# Altium schematic sheet Extra3_test_points.SchDoc
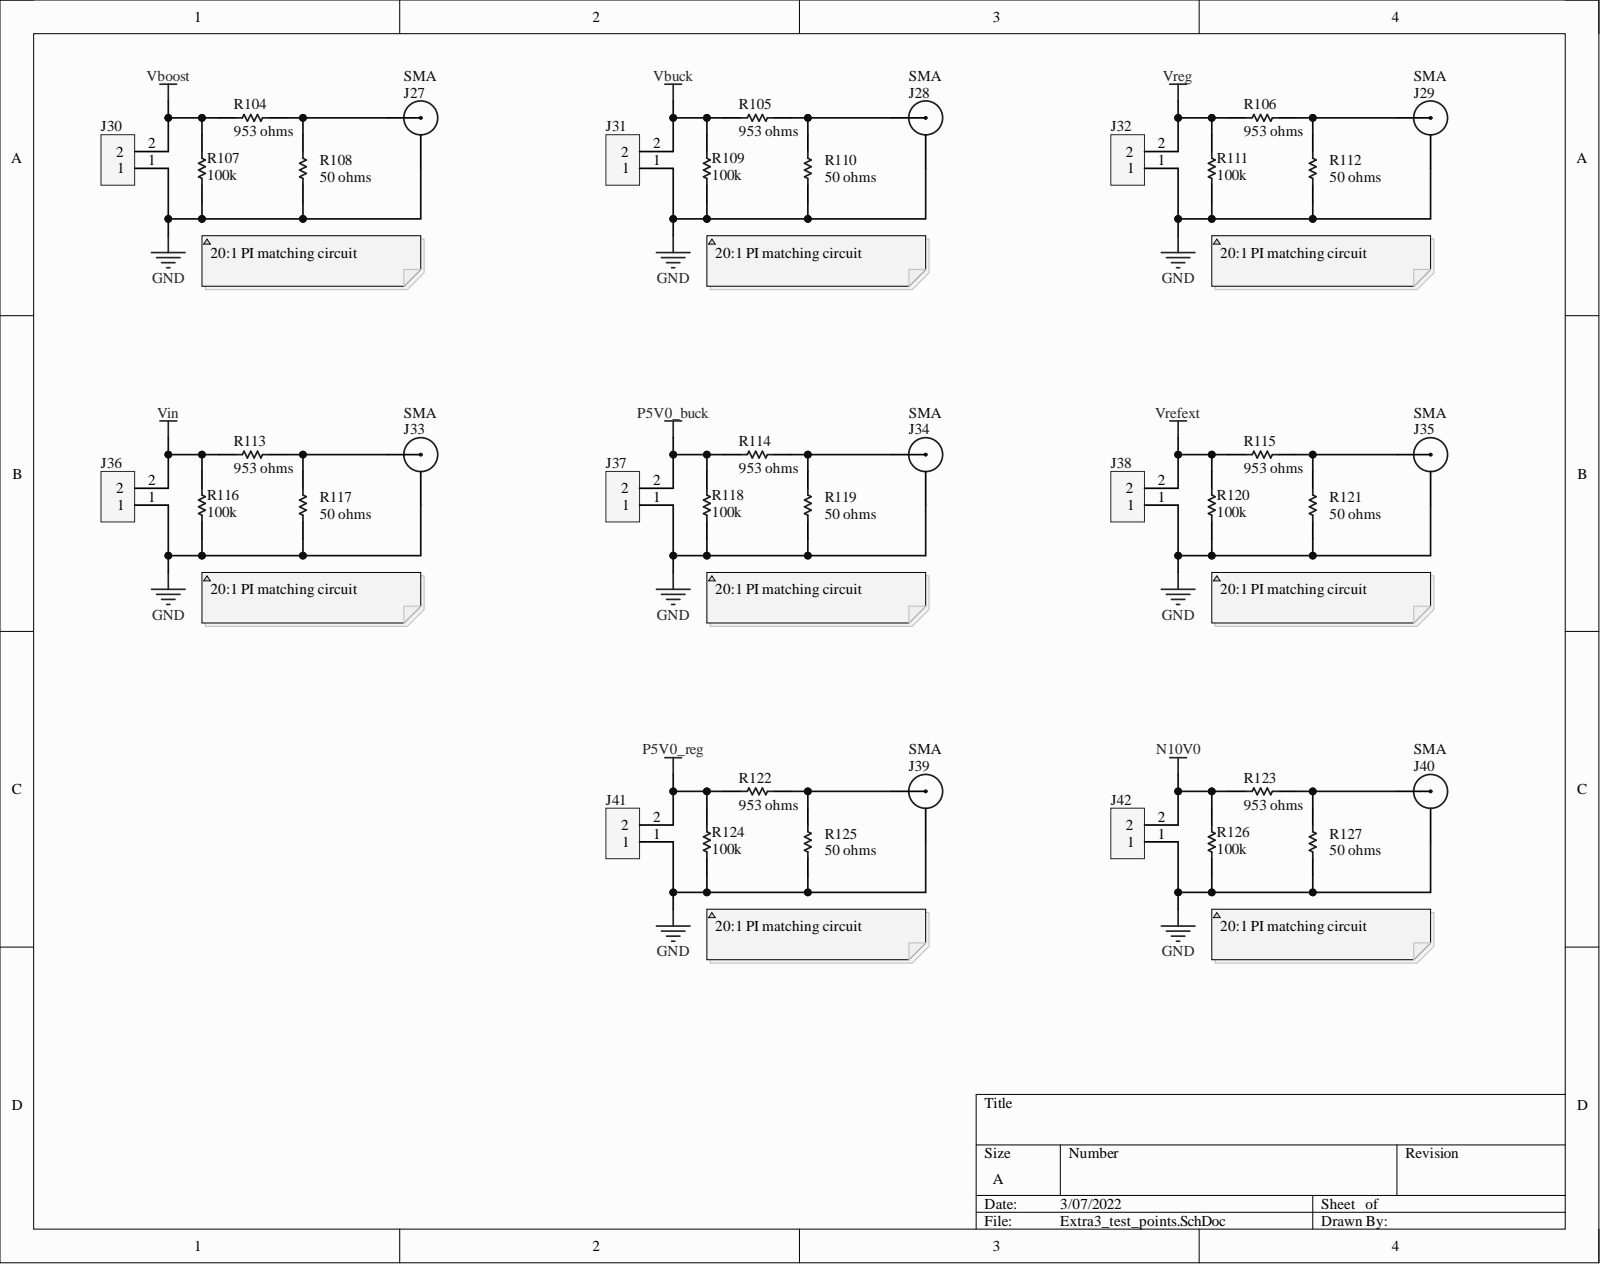
[diagram p0: Extra3_test_points.SchDoc]

## Parts
J27  SMA  [Amphenol RF 901-10511-2-Footprint-1]
J28  SMA  [Amphenol RF 901-10511-2-Footprint-1]
J29  SMA  [Amphenol RF 901-10511-2-Footprint-1]
J30  (no value)  [PCB-omgsbkhp90n7lpri88og-1]
J31  (no value)  [PCB-omgsbkhp90n7lpri88og-1]
J32  (no value)  [PCB-omgsbkhp90n7lpri88og-1]
J33  SMA  [Amphenol RF 901-10511-2-Footprint-1]
J34  SMA  [Amphenol RF 901-10511-2-Footprint-1]
J35  SMA  [Amphenol RF 901-10511-2-Footprint-1]
J36  (no value)  [PCB-omgsbkhp90n7lpri88og-1]
J37  (no value)  [PCB-omgsbkhp90n7lpri88og-1]
J38  (no value)  [PCB-omgsbkhp90n7lpri88og-1]
J39  SMA  [Amphenol RF 901-10511-2-Footprint-1]
J40  SMA  [Amphenol RF 901-10511-2-Footprint-1]
J41  (no value)  [PCB-omgsbkhp90n7lpri88og-1]
J42  (no value)  [PCB-omgsbkhp90n7lpri88og-1]
R104  953 ohms  [RESC2013X50X30NL20T20]
R105  953 ohms  [RESC2013X50X30NL20T20]
R106  953 ohms  [RESC2013X50X30NL20T20]
R107  100k  [PCB-p00ux61zw156yfuk4gsu-1]
R108  50 ohms  [PCB-4097432-1]
R109  100k  [PCB-p00ux61zw156yfuk4gsu-1]
R110  50 ohms  [PCB-4097432-1]
R111  100k  [PCB-p00ux61zw156yfuk4gsu-1]
R112  50 ohms  [PCB-4097432-1]
R113  953 ohms  [RESC2013X50X30NL20T20]
R114  953 ohms  [RESC2013X50X30NL20T20]
R115  953 ohms  [RESC2013X50X30NL20T20]
R116  100k  [PCB-p00ux61zw156yfuk4gsu-1]
R117  50 ohms  [PCB-4097432-1]
R118  100k  [PCB-p00ux61zw156yfuk4gsu-1]
R119  50 ohms  [PCB-4097432-1]
R120  100k  [PCB-p00ux61zw156yfuk4gsu-1]
R121  50 ohms  [PCB-4097432-1]
R122  953 ohms  [RESC2013X50X30NL20T20]
R123  953 ohms  [RESC2013X50X30NL20T20]
R124  100k  [PCB-p00ux61zw156yfuk4gsu-1]
R125  50 ohms  [PCB-4097432-1]
R126  100k  [PCB-p00ux61zw156yfuk4gsu-1]
R127  50 ohms  [PCB-4097432-1]

## Nets
GND: J27.2 J28.2 J29.2 J30.1 J31.1 J32.1 J33.2 J34.2 J35.2 J36.1 J37.1 J38.1 J39.2 J40.2 J41.1 J42.1 R107.1 R108.1 R109.1 R110.1 R111.1 R112.1 R116.1 R117.1 R118.1 R119.1 R120.1 R121.1 R124.1 R125.1 R126.1 R127.1
N10V0: J42.2 R123.1 R126.2
P5V0_buck: J37.2 R114.1 R118.2
P5V0_reg: J41.2 R122.1 R124.2
Vboost: J30.2 R104.1 R107.2
Vbuck: J31.2 R105.1 R109.2
Vin: J36.2 R113.1 R116.2
Vrefext: J38.2 R115.1 R120.2
Vreg: J32.2 R106.1 R111.2
NetJ27_1: J27.1 R104.2 R108.2
NetJ28_1: J28.1 R105.2 R110.2
NetJ29_1: J29.1 R106.2 R112.2
NetJ33_1: J33.1 R113.2 R117.2
NetJ34_1: J34.1 R114.2 R119.2
NetJ35_1: J35.1 R115.2 R121.2
NetJ39_1: J39.1 R122.2 R125.2
NetJ40_1: J40.1 R123.2 R127.2

## Library data of the parts
J27-J29 J33-J35 J39 J40 = CMP-c4510937ad5a1b96-2: RF Connector SMA PCB End Launch Jack 50 Ohm Round Flange with Flats High Freq 0.010 Pin - Footprint specifically for OSH Park 4 Layer!; Manufacturer Part Number: 901-10511-2; Component Kind: Standard; Contact Material: Beryllium Copper; Contact Plating: Gold; Footprint1NoteToUser: Footprint-1 designed specifically for OSH Park 4 Layer Process; Library Name: My Personal Space; Octopart Url: https://octopart.com/901-10511-2-amphenol+rf-74206317; OctopartID: c4510937ad5a1b96; RoHS: Compliant
J30-J32 J36-J38 J41 J42 = CMP-748fbcb73d6bab48-30: Pitch 2.54 mm, Single Row, 2pins; Component Kind: Standard; Library Name: My Personal Space
R104-R106 R113-R115 R122 R123 = CMP-1867576-2: RES SMD 953 OHM 1% 1/8W 0805; Manufacturer Part Number: CRCW0805953RFKEA; Case/Package (SI): 2012; Lead-Free Status: Lead Free; Power Rating: 0.125 W; Resistance: 953.0 Ω; Temperature Coefficient: 100.0 ppm/°C; Voltage Rating (DC): 150.0 V; Case/Package: 0805; Component Kind: Standard; Library Name: My Personal Space; Mounting Style: Surface Mount; Number of Pins: 2; Octopart Url: https://octopart.com/crcw0805953rfkea-vishay+dale-39800147; OctopartID: 3280f9d55ab5ed47; REACH SVHC Compliance: No SVHC; Resistance Tolerance: ±1%; RoHS: Compliant; Size-Height: 0.00045 m; Size-Length: 0.002 m; Size-Width: 0.00125 m
R107 R109 R111 R116 R118 R120 R124 R126 = CMP-1854318-20: Res Thick Film 0805 100K Ohm 1% 1/8W ±100ppm/°C Molded SMD Paper T/R; Manufacturer Part Number: RC0805FR-07100KL; Case/Package (SI): 2012; Lead-Free Status: Lead Free; Power Rating: 125 mW; Resistance: 100 kΩ; Temperature Coefficient: -100 ppm/°C to 100 ppm/°C; Voltage Rating (DC): 150 V; Case/Package: 0805; Component Kind: Standard; Library Name: My Personal Space; Mounting Style: Surface Mount; Number of Pins: 2; Octopart URL: https://octopart.com/rc0805fr-07100kl-yageo-40025548; OctopartID: f9d232ba61782615; Operating Temperature: 155 °C (max); Packaging: Tape & Reel; REACH SVHC Compliance: No SVHC; Resistance Tolerance: ±1%; RoHS: Compliant; Size-Height: 500 µm; Size-Length: 2.00 mm; Size-Width: 1.25 mm
R108 R110 R112 R117 R119 R121 R125 R127 = CMP-03acc8c67e5fdc6b-1: RT Series 0805 0.125 W 15 kOhm ±1 % ±50 ppm/°C SMT Thin Film Chip Resistor; Manufacturer Part Number: RT0805FRE0715KL; Case/Package (SI): 2012; Lead-Free Status: Lead Free; Power Rating: 0.125 W; Resistance: 15000.0 Ω; Resistance Tolerance: ±1%; Voltage Rating (DC): 150.0 V; Case/Package: 0805; Component Kind: Standard; Library Name: My Personal Space; Mounting Style: Surface Mount; Number of Pins: 2; Octopart URL: http://octopart.com/rt0805fre0715kl-yageo-39868565; OctopartID: 03acc8c67e5fdc6b; Packaging: Tape, Tape & Reel (TR); REACH SVHC Compliance: No SVHC; RoHS: Compliant; Size-Height: 0.0005 m; Size-Length: 0.002 m; Size-Width: 0.00125 m
